# Altium schematic sheet RF.SchDoc(RF)
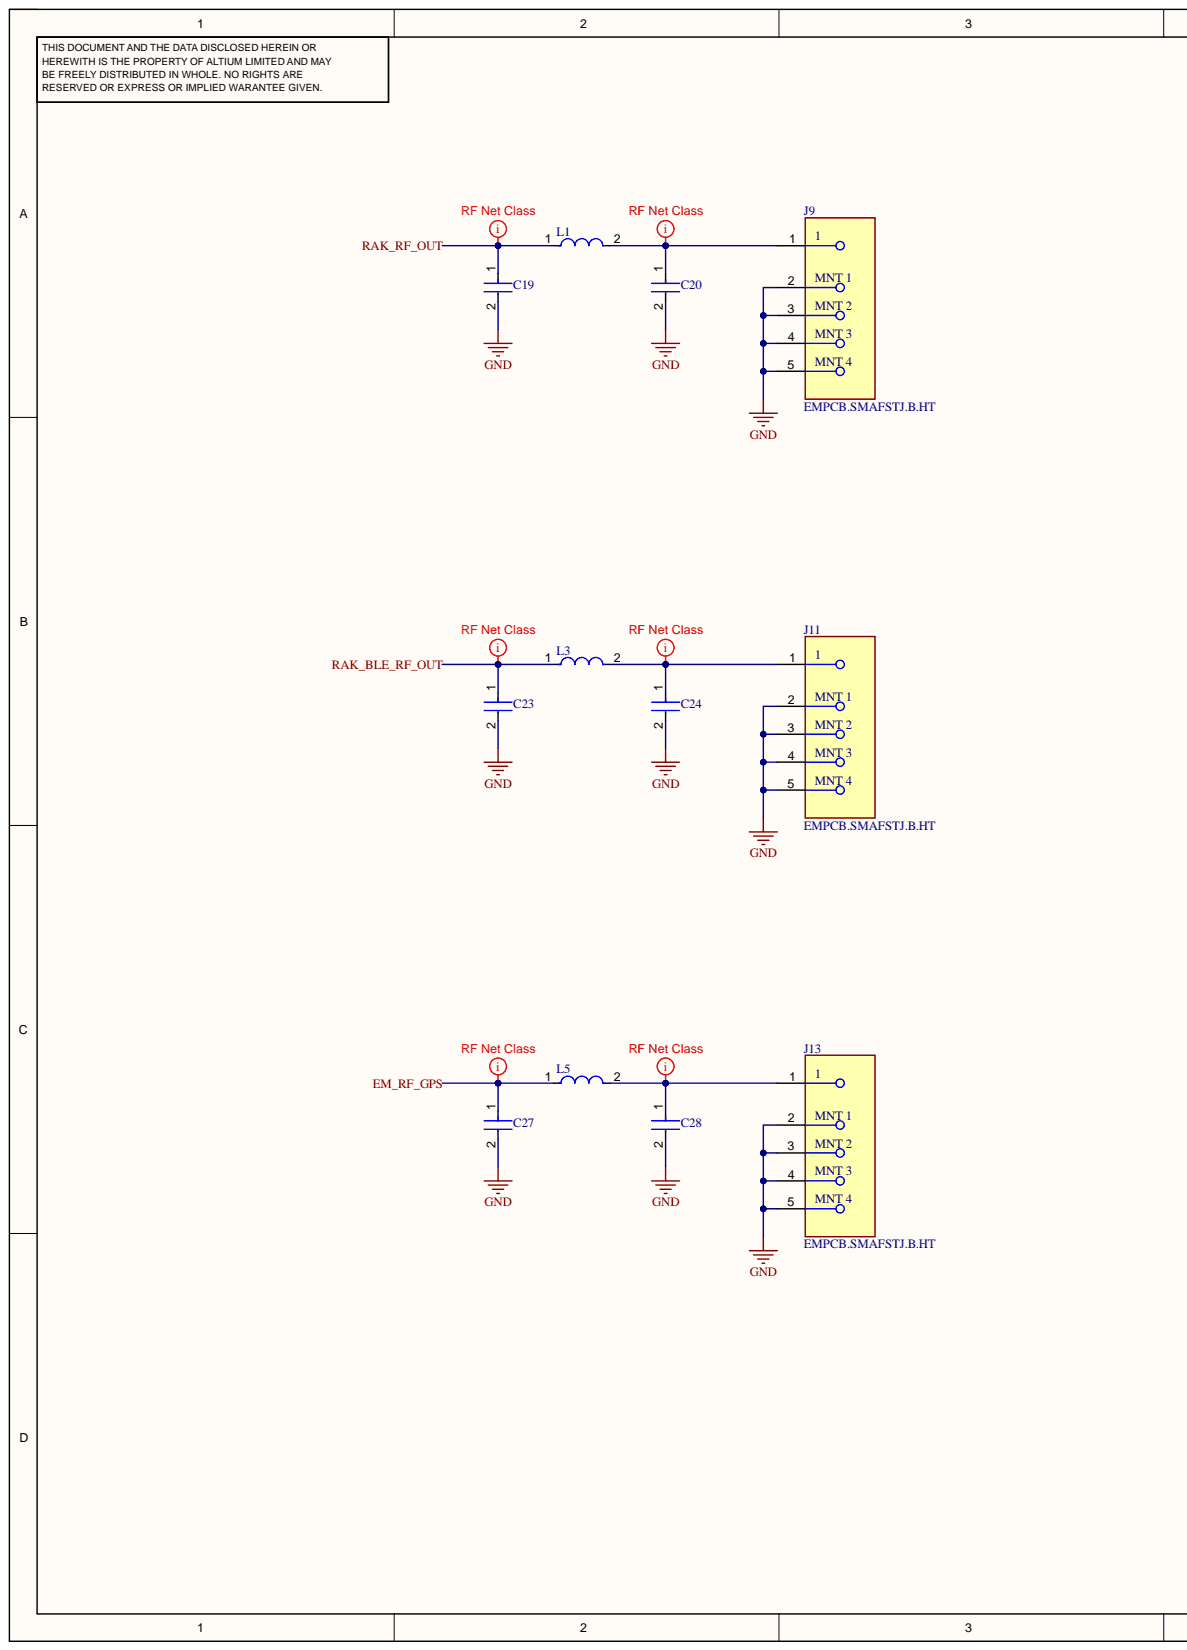
[diagram p0: RF.SchDoc, left]
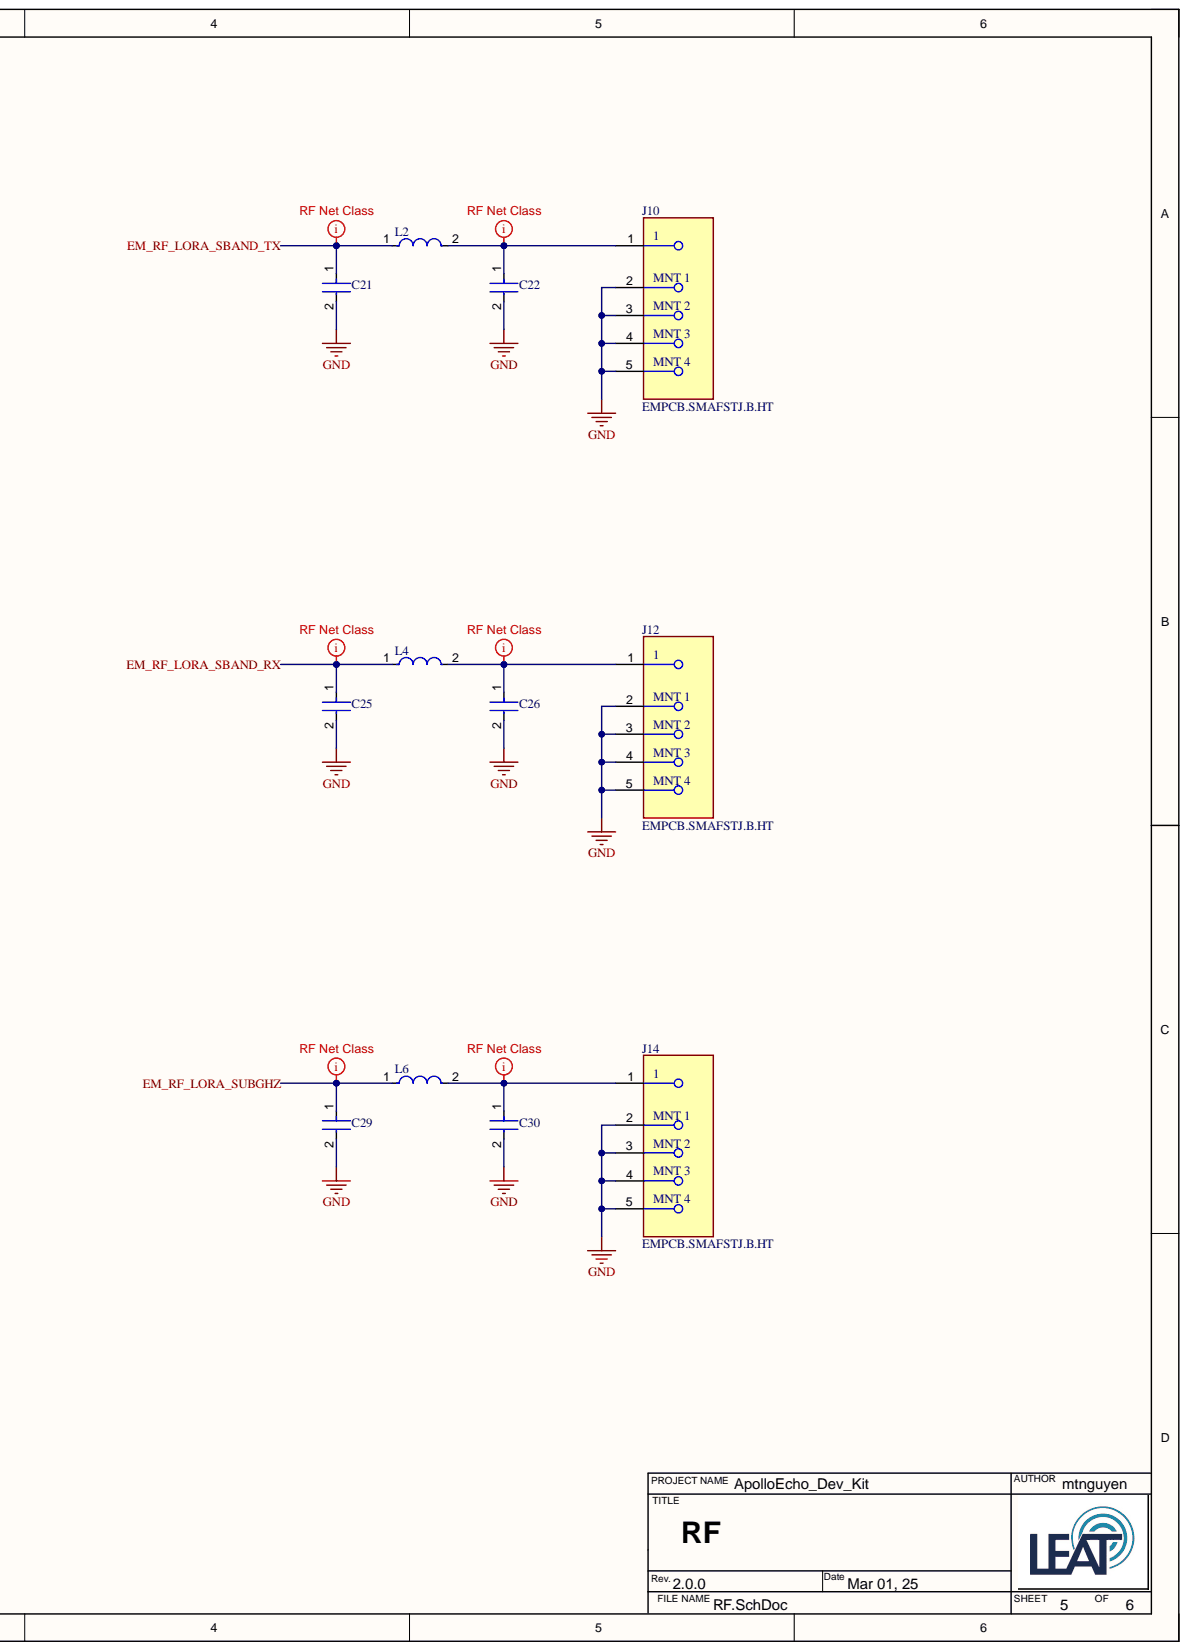
[diagram p1: RF.SchDoc, right]

## Parts
C19  GJM1555C1H5R0CB01D  [FP-GJM155-0_05-MFG]  (value not drawn)
C20  GJM1555C1H5R0CB01D  [FP-GJM155-0_05-MFG]  (value not drawn)
C21  GJM1555C1H5R0CB01D  [FP-GJM155-0_05-MFG]  (value not drawn)
C22  GJM1555C1H5R0CB01D  [FP-GJM155-0_05-MFG]  (value not drawn)
C23  GJM1555C1H5R0CB01D  [FP-GJM155-0_05-MFG]  (value not drawn)
C24  GJM1555C1H5R0CB01D  [FP-GJM155-0_05-MFG]  (value not drawn)
C25  GJM1555C1H5R0CB01D  [FP-GJM155-0_05-MFG]  (value not drawn)
C26  GJM1555C1H5R0CB01D  [FP-GJM155-0_05-MFG]  (value not drawn)
C27  GJM1555C1H5R0CB01D  [FP-GJM155-0_05-MFG]  (value not drawn)
C28  GJM1555C1H5R0CB01D  [FP-GJM155-0_05-MFG]  (value not drawn)
C29  GJM1555C1H5R0CB01D  [FP-GJM155-0_05-MFG]  (value not drawn)
C30  GJM1555C1H5R0CB01D  [FP-GJM155-0_05-MFG]  (value not drawn)
J9  EMPCB.SMAFSTJ.B.HT  [FP-EMPCB_SMAFSTJ_B_HT-MFG]
J10  EMPCB.SMAFSTJ.B.HT  [FP-EMPCB_SMAFSTJ_B_HT-MFG]
J11  EMPCB.SMAFSTJ.B.HT  [FP-EMPCB_SMAFSTJ_B_HT-MFG]
J12  EMPCB.SMAFSTJ.B.HT  [FP-EMPCB_SMAFSTJ_B_HT-MFG]
J13  EMPCB.SMAFSTJ.B.HT  [FP-EMPCB_SMAFSTJ_B_HT-MFG]
J14  EMPCB.SMAFSTJ.B.HT  [FP-EMPCB_SMAFSTJ_B_HT-MFG]
L1  LQW15AN2N9D00D  [FP-LQW15AN_00-W0_6-0_1-MFG]  (value not drawn)
L2  LQW15AN2N9D00D  [FP-LQW15AN_00-W0_6-0_1-MFG]  (value not drawn)
L3  LQW15AN2N9D00D  [FP-LQW15AN_00-W0_6-0_1-MFG]  (value not drawn)
L4  LQW15AN2N9D00D  [FP-LQW15AN_00-W0_6-0_1-MFG]  (value not drawn)
L5  LQW15AN2N9D00D  [FP-LQW15AN_00-W0_6-0_1-MFG]  (value not drawn)
L6  LQW15AN2N9D00D  [FP-LQW15AN_00-W0_6-0_1-MFG]  (value not drawn)

## Nets
GND: C19.2 C20.2 C21.2 C22.2 C23.2 C24.2 C25.2 C26.2 C27.2 C28.2 C29.2 C30.2 J9.2 J9.3 J9.4 J9.5 J10.2 J10.3 J10.4 J10.5 J11.2 J11.3 J11.4 J11.5 J12.2 J12.3 J12.4 J12.5 J13.2 J13.3 J13.4 J13.5 J14.2 J14.3 J14.4 J14.5
EM_RF_GPS: C27.1 L5.1
EM_RF_LORA_SBAND_RX: C25.1 L4.1
EM_RF_LORA_SBAND_TX: C21.1 L2.1
EM_RF_LORA_SUBGHZ: C29.1 L6.1
RAK_BLE_RF_OUT: C23.1 L3.1
RAK_RF_OUT: C19.1 L1.1
NetC20_1: C20.1 J9.1 L1.2
NetC22_1: C22.1 J10.1 L2.2
NetC24_1: C24.1 J11.1 L3.2
NetC26_1: C26.1 J12.1 L4.2
NetC28_1: C28.1 J13.1 L5.2
NetC30_1: C30.1 J14.1 L6.2

## Library data of the parts
C19-C30 = CMP-2008-03860-2: Multi-Layer Ceramic Capacitor 5pF C0G  ±0.25pF 0402 Paper T/R; Voltage Rating: 50V; Capacitance: 5pF; Case Code (Imperial): 0402; Case Code (Metric): 1005; Case/Package: 0402; Component Kind: Standard; Depth: 0.5mm; Dielectric: C0G; Height: 0.5mm; Lead Free: Lead Free; Length: 1mm; Max Operating Temperature: 125°C; Min Operating Temperature: -55°C; Mount: Surface Mount; Package Quantity: 1; Packaging: Tape and Reel; Radiation Hardening: No; Termination: SMD/SMT; Thickness: 0.022inch; Voltage Rating (DC): 50V; Width: 0.02inch; Library Name: ApolloEcho_Dev_Kit.IntLib
J9-J14 = CMP-111093-000001-1: CONN SMA JACK STR 50OHM EDGE MNT; Manufacturer: Taoglas Limited; Conn Orientation: Horizontal; Frequency: 12.4GHz; Impedance: 50Ω; Is Female: yes; Number Of Contacts: 1; Pitch: 2.62mm; Voltage Rating: 335V; Automotive: No; Category: Conn; Component Kind: Standard; ComponentLink2Description: Datasheet; ComponentLink2URL: https://cdn.taoglas.com/datasheets/EMPCB.SMAFSTJ.B.HT.pdf; Contact Material: Gold; Device Class L1: Connectors; Device Class L2: RF / Coaxial Connectors; Device Class L3: unset; Digikey Description: CONN SMA JACK STR 50OHM EDGE MNT; Lead Free: Yes; Manufacturer Part Number: EMPCB.SMAFSTJ.B.HT; Mouser Description: RF Connectors / Coaxial Connectors SMA Straight Edge Mount PCB Jack-Gold; Octopart: https://octopart.com/empcb.smafstj.b.ht-taoglas-24204431; Package: 0; RoHS: TRUE; Temperature: -40°C to +85°C; Temperature Range High: +85°C; Temperature Range Low: -40°C; Library Name: ApolloEcho_Dev_Kit.IntLib
L1-L6 = CMP-06042-011289-1: Wire Wound RF Inductor 2.9nH ±0.5nH 750mA 0.07Ω 0402 (1005); Core Material: Non-Magnetic; DC Resistance (DCR): 70mR; Q Factor: 20; Self Resonant Frequency: 15GHz; Shielding: Unshielded; Test Frequency: 100MHz; Case Code (Imperial): 0402; Case Code (Metric): 1005; Case/Package: 0402; Component Kind: Standard; Current Rating: 750mA; DC Current: 750mA; Depth: 0.7mm; Height: 0.5mm; Height - Seated (Max): 0.024inch; Inductance: 2.9nH; Length: 1mm; Max DC Current: 750mA; Max Operating Temperature: 125°C; Military Standard: Not; Min Operating Temperature: -55°C; Mount: Surface Mount; Package Quantity: 1; Packaging: Tape and Reel; Radiation Hardening: No; Resistor Type: Wirewound; Termination: SMD/SMT; Weight: 2.8E-05oz; Width: 0.02inch; Library Name: ApolloEcho_Dev_Kit.IntLib
